# Altium schematic sheet Sheet3.SchDoc(Sheet3)
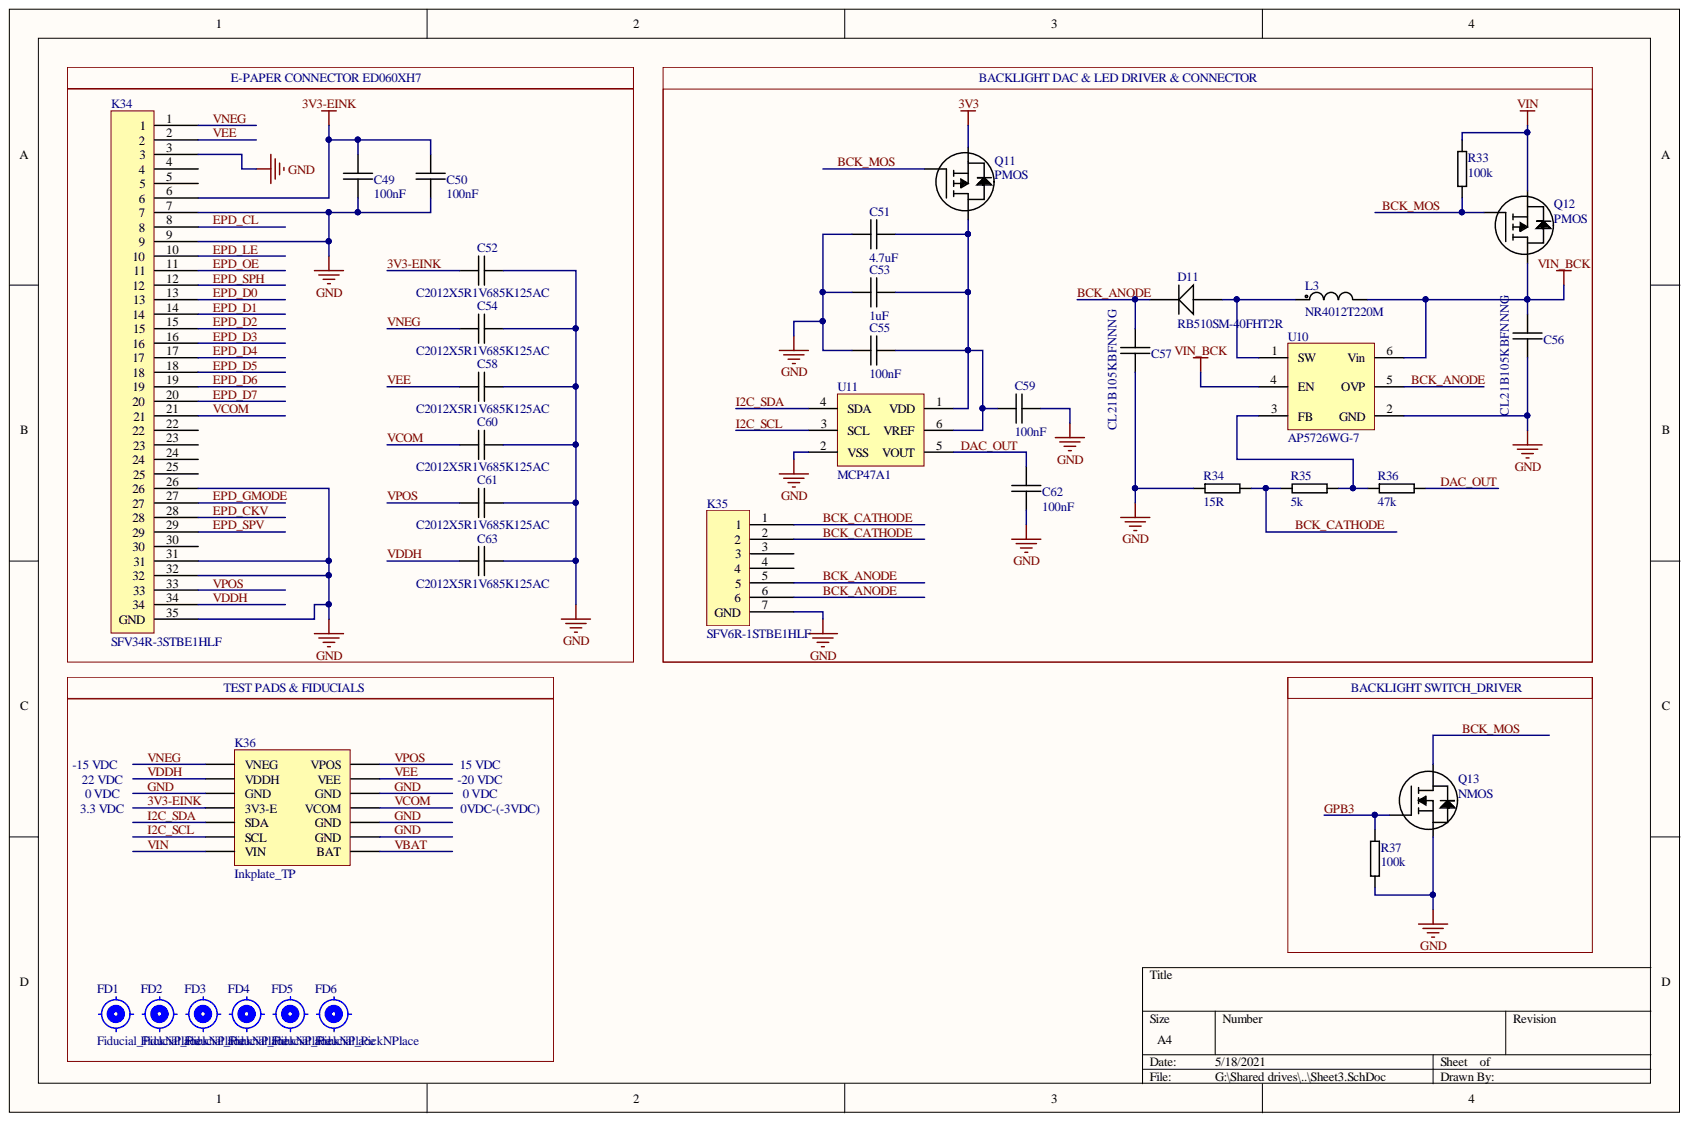
[diagram p0: Sheet3.SchDoc]

## Parts
C49  100nF  [0603C]
C50  100nF  [0603C]
C51  4.7uF  [0603C]
C52  C2012X5R1V685K125AC  [0805C]
C53  1uF  [0603C]
C54  C2012X5R1V685K125AC  [0805C]
C55  100nF  [0603C]
C56  CL21B105KBFNNNG  [0805C]
C57  CL21B105KBFNNNG  [0805C]
C58  C2012X5R1V685K125AC  [0805C]
C59  100nF  [0603C]
C60  C2012X5R1V685K125AC  [0805C]
C61  C2012X5R1V685K125AC  [0805C]
C62  100nF  [0603C]
C63  C2012X5R1V685K125AC  [0805C]
D11  RB510SM-40FHT2R  [RB510SM-40FHT2R SOD523-2]
FD1  Fiducial_PickNPlace  [Fiducial_1mm_NO-Paste]  (no BOM)
FD2  Fiducial_PickNPlace  [Fiducial_1mm_NO-Paste]  (no BOM)
FD3  Fiducial_PickNPlace  [Fiducial_1mm_NO-Paste]  (no BOM)
FD4  Fiducial_PickNPlace  [Fiducial_1mm_NO-Paste]  (no BOM)
FD5  Fiducial_PickNPlace  [Fiducial_1mm_NO-Paste]  (no BOM)
FD6  Fiducial_PickNPlace  [Fiducial_1mm_NO-Paste]  (no BOM)
K34  SFV34R-3STBE1HLF  [SFV34R-3STBE1HLF]
K35  SFV6R-1STBE1HLF  [SFV6R-1STBE1HLF]
K36  Inkplate_TP  [Inkplate test header]
L3  NR4012T220M  [NR4012T2R2M_Fixed Inductor]
Q11  PMOS  [SOT-23-3]
Q12  PMOS  [SOT-23-3]
Q13  NMOS  [SOT-23-3]
R33  100k  [0603R]
R34  15R  [0603R]
R35  5k  [0603R]
R36  47k  [0603R]
R37  100k  [0603R]
U10  AP5726WG-7  [SOT-23-6]
U11  MCP47A1  [MCP47A1 SOT-23-6]

## Nets
3V3: Q11.2
VIN_BCK: C56.2 L3.2 Q12.3 U10.4(EN) U10.6(Vin)
3V3-EINK: C49.1 C50.1 C52.1 K34.6 K36.4(3V3-E)
BCK_ANODE: C57.2 D11.1 K35.5 K35.6 U10.5(OVP)
BCK_CATHODE: K35.1 K35.2 R34.1 R35.1
BCK_MOS: Q11.1 Q12.1 Q13.3 R33.1
DAC_OUT: C62.1 R36.1 U11.5(VOUT)
EPD_CKV: K34.28
EPD_CL: K34.8
EPD_D0: K34.13
EPD_D1: K34.14
EPD_D2: K34.15
EPD_D3: K34.16
EPD_D4: K34.17
EPD_D5: K34.18
EPD_D6: K34.19
EPD_D7: K34.20
EPD_GMODE: K34.27
EPD_LE: K34.10
EPD_OE: K34.11
EPD_SPH: K34.12
EPD_SPV: K34.29
GND: C49.2 C50.2 C51.2 C52.2 C53.2 C54.2 C55.2 C56.1 C57.1 C58.2 C59.1 C60.2 C61.2 C62.2 C63.2 K34.3 K34.7 K34.9 K34.26 K34.31 K34.32 K34.35(GND) K35.7(GND) K36.3(GND) K36.9(GND) K36.10(GND) K36.12(GND) Q13.2 R34.2 R37.1 U10.2(GND) U11.2(VSS)
GPB3: Q13.1 R37.2
I2C_SCL: K36.6(SCL) U11.3(SCL)
I2C_SDA: K36.5(SDA) U11.4(SDA)
VBAT: K36.8(BAT)
VCOM: C60.1 K34.21 K36.11(VCOM)
VDDH: C63.1 K34.34 K36.2(VDDH)
VEE: C58.1 K34.2 K36.13(VEE)
VIN: K36.7(VIN) Q12.2 R33.2
VNEG: C54.1 K34.1 K36.1(VNEG)
VPOS: C61.1 K34.33 K36.14(VPOS)
NetC51_1: C51.1 C53.1 C55.1 C59.2 Q11.3 U11.1(VDD) U11.6(VREF)
NetD11_2: D11.2 L3.1 U10.1(SW)
NetR35_2: R35.2 R36.2 U10.3(FB)

Not connected: K34 4 5 22 23 24 25 30; K35 3 4

## Library data of the parts
C49-C51 C53 C55 C59 C62 = 0603C: Component Kind: Standard; Library Name: e-radionica.com schematics.SchLib
C52 C54 C56-C58 C60 C61 C63 = 0805C: Component Kind: Standard; Library Name: e-radionica.com schematics.SchLib
D11 = RB510SM-40FHT2R: Inkplate 6+; Component Kind: Standard; Library Name: e-radionica.com schematics.SchLib
FD1-FD6 = Fiducial_PickNPlace: ROUND 1mm; Library Name: e-radionica.com schematics.SchLib
K35 = SFV6R-1STBE1HLF: CONN FFC BOTTOM 6POS 0.50MM R/A; Manufacturer: Amphenol ICC / FCI; Voltage Rating: 50 V; Pitch: 0.5 mm; Number of Positions / Contacts: 6; Mounting Angle: Right; Insulation Resistance: 100 MOhms; Current Rating: 500 mA; Contact Location: Bottom Contact; Brand: FCI; Actuation Type: ZIF; Termination Style: SMD; Temperature Range: - 55 C to + 105 C; Supplier Part Number 1: 649-SFV6R-1STBE1HLF; Supplier 1: Mouser; Stock 1: 891; Series: SFV-R; RoHS: Details; Product Type: Board Mount; Product Category: FFC & FPC Connectors; Pricing 1: 1=0.38, 10=0.26, 50=0.26, 100=0.214, 1000=0.179, 10000=0.137 (USD); Packaging: Reel; Number of Positions: 6; Mounting Style: SMD/SMT; Manufacturer Part Number: SFV6R-1STBE1HLF; Housing Material: Polyamide Resin; Factory Pack Quantity: 3000; Contact Plating: Gold; Contact Material: Phosphor Bronze; ComponentLink1URL: https://cdn.amphenol-icc.com/media/wysiwyg/files/drawing/sfv12b_hlf.pdf?src-supplier=Digi-Key; ComponentLink1Description: https://cdn.amphenol-icc.com/media/wysiwyg/files/drawing/sfv12b_hlf.pdf?src-supplier=Digi-Key; Component Kind: Standard; Library Name: e-radionica.com schematics.SchLib
K36 = Inkplate test header: Component Kind: Standard; Library Name: e-radionica.com schematics.SchLib
L3 = NR4012T2R2M: FIXED IND 2.2UH 1.2A 108 MOHM; Manufacturer: Taiyo Yuden; Tolerance: 20%; Test Frequency: 100kHz; Shielding: Shielded; Self Resonant Frequency: 66MHz; Saturation Current: 1.65A; DC Resistance (DCR): 90mR; Core Material: Ferrite; Width: 4mm; Termination: SMD/SMT; Supplier Part Number 1: 587-1661-6-ND; Supplier 1: Digi-Key; Stock 1: 1790; RMS Current (Irms): 1.2A; REACH SVHC: Unknown; Pricing 1: 1=0.44, 10=0.382, 100=0.2731, 500=0.22942, 1000=0.18573 (USD); Packaging: Cut Tape; Package Quantity: 4500; Mount: Surface Mount; Min Operating Temperature: -25°C; Max Operating Temperature: 120°C; Max DC Current: 1.65A; Manufacturer Part Number: NR4012T2R2M; Length: 0.157inch; Lead Free: Lead Free; Inductance Tolerance: 20%; Inductance: 2.2uH; Height - Seated (Max): 0.047inch; Height: 1.2mm; Halogen Free: Halogen Free; Current Rating: 1.2A; Composition: Wirewound; ComponentLink2URL: http://www.yuden.co.jp/productdata/catalog/en/wound04_e.pdf?src-supplier=Digi-Key; ComponentLink2Description: http://www.yuden.co.jp/productdata/catalog/en/wound04_e.pdf?src-supplier=Digi-Key; ComponentLink1URL: http://www.yuden.co.jp/productdata/catalog/en/wound04_e.pdf?src-supplier=Avnet; ComponentLink1Description: http://www.yuden.co.jp/productdata/catalog/en/wound04_e.pdf?src-supplier=Avnet; Component Kind: Standard; Category: Fixed Inductors; Case/Package: Nonstandard; Library Name: e-radionica.com schematics.SchLib
Q11 Q12 = PMOS SOT-23-3: Supplier Part Number 3: DMP3120L-7; Supplier Part Number 2: 621-DMP3120L-7; Supplier Part Number 1: DMP3120L-7DI-ND; Supplier 3: Arrow; Supplier 2: Mouser; Supplier 1: Digi-Key; Component Kind: Standard; Library Name: e-radionica.com schematics.SchLib
Q13 = NMOS SOT-23-3: Supplier Part Number 3: ZXMN2A14FCT-ND; Supplier Part Number 2: ZXMN2A14FTA; Supplier Part Number 1: 522-ZXMN2A14FTA; Supplier 3: Digi-Key; Supplier 2: Arrow; Supplier 1: Mouser; Component Kind: Standard; Library Name: e-radionica.com schematics.SchLib
R33-R37 = 0603R: Component Kind: Standard; Library Name: e-radionica.com schematics.SchLib
U10 = AP5726WG-7: inkplate 6+; Component Kind: Standard; Library Name: e-radionica.com schematics.SchLib
U11 = MCP47A1: Inkplate 6+; Component Kind: Standard; Library Name: e-radionica.com schematics.SchLib
K34 = SFV34R-3STBE1HLF: Component Kind: Standard; Library Name: e-radionica.com schematics.SchLib
